# Altium schematic sheet PowerSupply.SchDoc(PowerSupply)
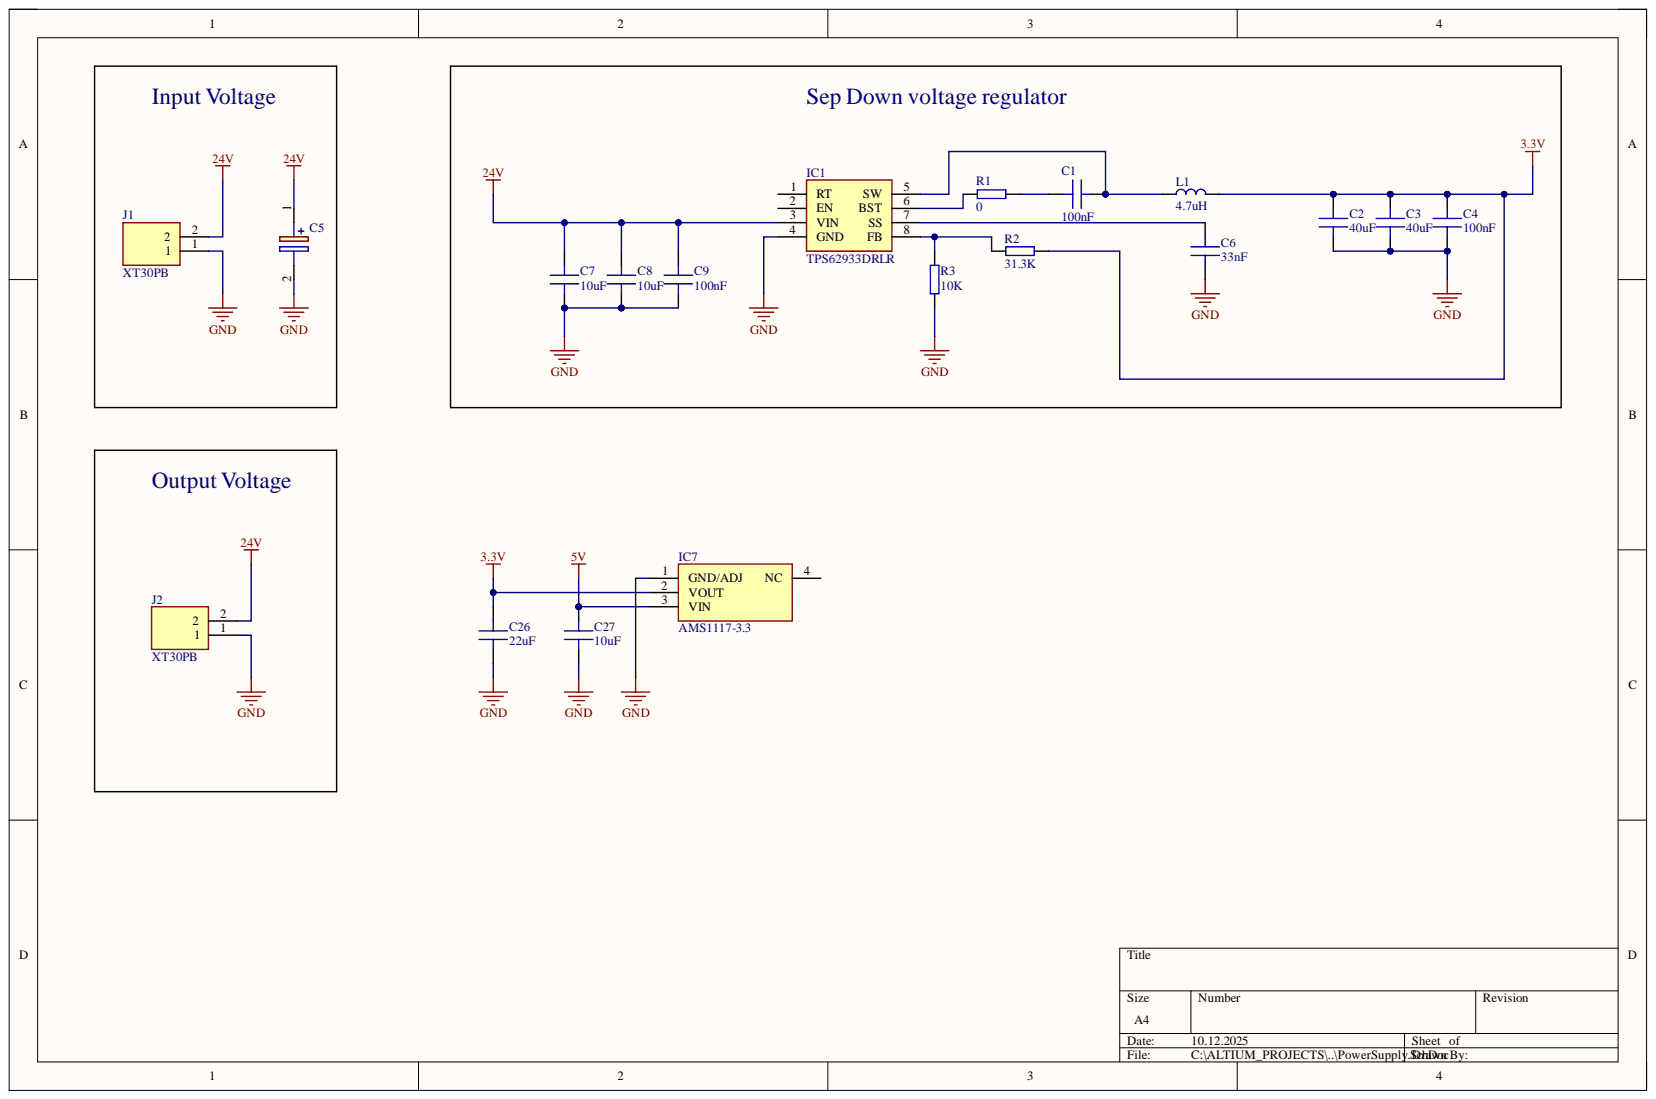
[diagram p0: PowerSupply.SchDoc]

## Parts
C1  100nF  [C 0402]
C2  40uF  [C 1206]
C3  40uF  [C 1206]
C4  100nF  [C 0603]
C5  UVY1V101MED1TD  [CAPPRD250W50D655H1250]  (value not drawn)
C6  33nF  [C 0402]
C7  10uF  [C 1206]
C8  10uF  [C 1206]
C9  100nF  [C 0603]
C26  22uF  [C 0805]
C27  10uF  [C 0805]
IC1  TPS62933DRLR  [SOTFL50P160X60-8N]
IC7  AMS1117-3.3  [SOT229P700X180-4N]
J1  XT30PB  [XT30PB]
J2  XT30PB  [XT30PB]
L1  4.7uH  [HPI0630]
R1  0  [R 0402]
R2  31.3K  [R 0402]
R3  10K  [R 0402]

## Nets
3.3V: C2.1 C3.1 C4.1 C26.1 IC7.2(VOUT) L1.2 R2.1
5V: C27.1 IC7.3(VIN)
24V: C5.1 C7.1 C8.1 C9.1 IC1.3(VIN) J1.2 J2.2
GND: C2.2 C3.2 C4.2 C5.2 C6.2 C7.2 C8.2 C9.2 C26.2 C27.2 IC1.4(GND) IC7.1(GND/ADJ) J1.1 J2.1 R3.1
NetC1_1: C1.1 R1.1
NetC1_2: C1.2 IC1.5(SW) L1.1
NetC6_1: C6.1 IC1.7(SS)
NetIC1_6: IC1.6(BST) R1.2
NetIC1_8: IC1.8(FB) R2.2 R3.2

Not connected: IC1 1(RT) 2(EN); IC7 4(NC)

## Library data of the parts
C1-C4 C6-C9 C26 C27 = Capacitor: MLCC Capacitor; Component Kind: Standard; Library Name: Components.IntLib
C5 = UVY1V101MED1TD: Capacitor Polarised; Manufacturer_Name: Nichicon; Arrow Part Number: UVY1V101MED1TD; Arrow Price/Stock: https://www.arrow.com/en/products/uvy1v101med1td/nichicon; Component Kind: Standard; Datasheet Link: http://nichicon-us.com/english/products/pdfs/e-uvy.pdf; Height: 12.5mm; Library Name: lf_esp_sch_lib.SchLib; Manufacturer_Part_Number: UVY1V101MED1TD
IC1 = TPS62933DRLR: Integrated Circuit; Manufacturer_Name: Texas Instruments; Arrow Part Number: TPS62933DRLR; Arrow Price/Stock: https://www.arrow.com/en/products/tps62933drlr/texas-instruments?utm_currency=USD&region=nac; Component Kind: Standard; Datasheet Link: https://www.ti.com/lit/ds/symlink/tps62933.pdf?ts=1626073394234; Height: 0.6mm; Manufacturer_Part_Number: TPS62933DRLR; Mouser Part Number: 595-TPS62933DRLR; Mouser Price/Stock: https://www.mouser.co.uk/ProductDetail/Texas-Instruments/TPS62933DRLR?qs=Rp5uXu7WBW9fv47wfQVntQ%3D%3D
IC7 = AMS1117-3.3: Integrated Circuit; Manufacturer_Name: Advanced; Component Kind: Standard; Datasheet Link: https://datasheet.datasheetarchive.com/originals/distributors/Datasheets-28/DSA-550594.pdf; Height: 1.8mm; Manufacturer_Part_Number: AMS1117-3.3
J1 J2 = XT30PB: Connector; Manufacturer_Name: Amass; Component Kind: Standard; Datasheet Link: https://www.tme.eu/Document/4acc913878197f8c2e30d4b8cdc47230/XT30UPB%20SPEC.pdf; Height: 10.7mm; Library Name: SamacSys.SchLib; Manufacturer_Part_Number: XT30PB
L1 = Inductor: General pourpouse inductor; Component Kind: Standard; Library Name: Components.IntLib
R1-R3 = Resistor: General pourpouse resistor; Component Kind: Standard; Library Name: Components.IntLib
